# Altium schematic sheet lv_io_retro.SchDoc
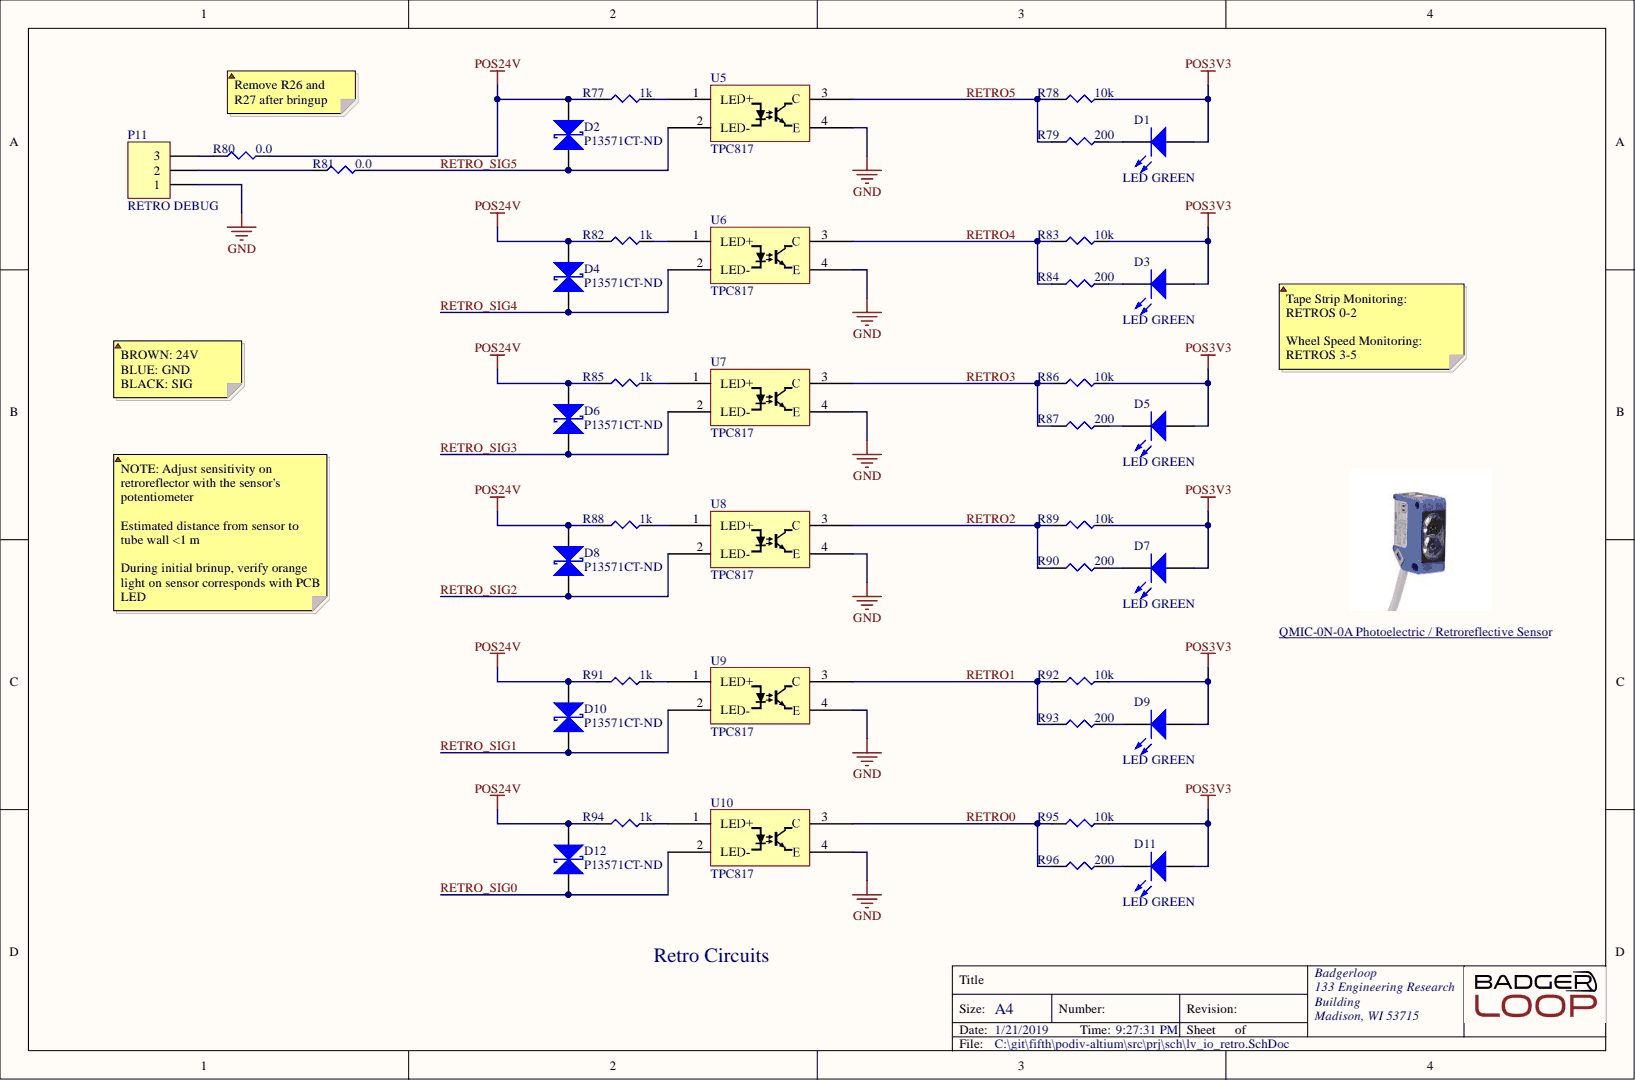
[diagram p0: lv_io_retro.SchDoc]

## Parts
D1  LED GREEN  Color: GREEN  [LED 0603 GREEN]
D2  Digikey P/N: P13571CT-ND  [SMD-0402-RES]  (hidden comment: ESD Diode)
D3  LED GREEN  Color: GREEN  [LED 0603 GREEN]
D4  Digikey P/N: P13571CT-ND  [SMD-0402-RES]  (hidden comment: ESD Diode)
D5  LED GREEN  Color: GREEN  [LED 0603 GREEN]
D6  Digikey P/N: P13571CT-ND  [SMD-0402-RES]  (hidden comment: ESD Diode)
D7  LED GREEN  Color: GREEN  [LED 0603 GREEN]
D8  Digikey P/N: P13571CT-ND  [SMD-0402-RES]  (hidden comment: ESD Diode)
D9  LED GREEN  Color: GREEN  [LED 0603 GREEN]
D10  Digikey P/N: P13571CT-ND  [SMD-0402-RES]  (hidden comment: ESD Diode)
D11  LED GREEN  Color: GREEN  [LED 0603 GREEN]
D12  Digikey P/N: P13571CT-ND  [SMD-0402-RES]  (hidden comment: ESD Diode)
P11  RETRO DEBUG  [Pin Header 1x3 TH Pitch 2.54mm]
R77  1k  [SMD-0603-RES]
R78  10k  [SMD-0603-RES]
R79  200  [SMD-0603-RES]
R80  0.0  [SMD-0402-RES]
R81  0.0  [SMD-0402-RES]
R82  1k  [SMD-0603-RES]
R83  10k  [SMD-0603-RES]
R84  200  [SMD-0603-RES]
R85  1k  [SMD-0603-RES]
R86  10k  [SMD-0603-RES]
R87  200  [SMD-0603-RES]
R88  1k  [SMD-0603-RES]
R89  10k  [SMD-0603-RES]
R90  200  [SMD-0603-RES]
R91  1k  [SMD-0603-RES]
R92  10k  [SMD-0603-RES]
R93  200  [SMD-0603-RES]
R94  1k  [SMD-0603-RES]
R95  10k  [SMD-0603-RES]
R96  200  [SMD-0603-RES]
U5  TPC817  [SOT200P1005X328-5N]
U6  TPC817  [SOT200P1005X328-5N]
U7  TPC817  [SOT200P1005X328-5N]
U8  TPC817  [SOT200P1005X328-5N]
U9  TPC817  [SOT200P1005X328-5N]
U10  TPC817  [SOT200P1005X328-5N]

## Nets
GND: P11.1 U5.4(E) U6.4(E) U7.4(E) U8.4(E) U9.4(E) U10.4(E)
POS3V3: D1.1 D3.1 D5.1 D7.1 D9.1 D11.1 R78.2 R83.2 R86.2 R89.2 R92.2 R95.2
POS24V: D2.2 D4.2 D6.2 D8.2 D10.2 D12.2 R77.1 R80.2 R82.1 R85.1 R88.1 R91.1 R94.1
RETRO0: R95.1 R96.1 U10.3(C)
RETRO1: R92.1 R93.1 U9.3(C)
RETRO2: R89.1 R90.1 U8.3(C)
RETRO3: R86.1 R87.1 U7.3(C)
RETRO4: R83.1 R84.1 U6.3(C)
RETRO5: R78.1 R79.1 U5.3(C)
RETRO_SIG0: D12.1 U10.2(LED-)
RETRO_SIG1: D10.1 U9.2(LED-)
RETRO_SIG2: D8.1 U8.2(LED-)
RETRO_SIG3: D6.1 U7.2(LED-)
RETRO_SIG4: D4.1 U6.2(LED-)
RETRO_SIG5: D2.1 R81.2 U5.2(LED-)
NetD1_2: D1.2 R79.2
NetD3_2: D3.2 R84.2
NetD5_2: D5.2 R87.2
NetD7_2: D7.2 R90.2
NetD9_2: D9.2 R93.2
NetD11_2: D11.2 R96.2
NetP11_2: P11.2 R81.1
NetP11_3: P11.3 R80.1
NetR77_2: R77.2 U5.1(LED+)
NetR82_2: R82.2 U6.1(LED+)
NetR85_2: R85.2 U7.1(LED+)
NetR88_2: R88.2 U8.1(LED+)
NetR91_2: R91.2 U9.1(LED+)
NetR94_2: R94.2 U10.1(LED+)

## Library data of the parts
D1 D3 D5 D7 D9 D11 = LED GREEN 0603: Manufacturer: Lite-On Inc.; Manufacturer P/N: LTST-C190KGKT; Component Kind: Standard; Digikey P/N: 160-1435-1-ND; Library Name: misc.SchLib; Package / Case: 0603
D2 D4 D6 D8 D10 D12 = ESD Diode: ESD Supressor; Manufacturer: EZAEG; Manufacturer P/N: Panasonic; Component Kind: Standard; Digikey Link: https://www.digikey.com/product-detail/en/panasonic-electronic-components/EZA-EG2A50AX/P13571CT-ND/1137239; Library Name: misc.SchLib
P11 = Header 3: Header, 3-Pin; Component Kind: Standard; LatestRevisionDate: 17-Jul-2002; LatestRevisionNote: Re-released for DXP Platform.; Library Name: connector.SchLib; Signal Integrity: Connector; Publisher: Altium Limited
R77 R82 R85 R88 R91 R94 = ERJ-P03F1001V: RES SMD 1K OHM 1% 1/5W 0603; Manufacturer: Panasonic Electronic Components; @ qty: 1500; Factory Stock: 0; Minimum Quantity: 1; Power (Watts): 0.2W, 1/5W; Temperature Coefficient: ±200ppm/°C; Unit Price (USD): 0.05227; Component Kind: Standard; Composition: Thick Film; Datasheets: https://industrial.panasonic.com/cdbs/www-data/pdf/RDA0000/AOA0000C243.pdf; Digi-Key Part Number: P16018CT-ND; Failure Rate: -; Features: Automotive AEC-Q200, Pulse Withstanding; Height - Seated (Max): 0.022" (0.55mm); Image: //media.digikey.com/Photos/Panasonic%20Photos/MFG_ERJ-P6W%20Series.jpg; Library Name: resistor.SchLib; Number of Terminations: 2; Operating Temperature: -55°C ~ 155°C; Package / Case: 0603 (1608 Metric); Packaging: Cut Tape (CT); Part Number: ERJ-P03F1001V; Part Status: Active; Quantity Available: 3331; Series: ERJ; Size / Dimension: 0.063" L x 0.031" W (1.60mm x 0.80mm); Supplier Device Package: 0603; Tolerance: ±1%; Value: 1k
R78 R83 R86 R89 R92 R95 = ERJ-P03J103V: RES SMD 10K OHM 5% 1/5W 0603; Manufacturer: Panasonic Electronic Components; @ qty: 1500; Factory Stock: 0; Minimum Quantity: 1; Power (Watts): 0.2W, 1/5W; Temperature Coefficient: ±200ppm/°C; Unit Price (USD): 0.03584; Component Kind: Standard; Composition: Thick Film; Datasheets: https://industrial.panasonic.com/cdbs/www-data/pdf/RDA0000/AOA0000C243.pdf; Digi-Key Part Number: P16032CT-ND; Failure Rate: -; Features: Automotive AEC-Q200, Pulse Withstanding; Height - Seated (Max): 0.022" (0.55mm); Image: //media.digikey.com/Photos/Panasonic%20Photos/MFG_ERJ-P6W%20Series.jpg; Library Name: resistor.SchLib; Number of Terminations: 2; Operating Temperature: -55°C ~ 155°C; Package / Case: 0603 (1608 Metric); Packaging: Cut Tape (CT); Part Number: ERJ-P03J103V; Part Status: Active; Quantity Available: 857; Series: ERJ; Size / Dimension: 0.063" L x 0.031" W (1.60mm x 0.80mm); Supplier Device Package: 0603; Tolerance: ±5%; Value: 10k
R79 R84 R87 R90 R93 R96 = ERJ-P03J201V: RES SMD 200 OHM 5% 1/5W 0603; Manufacturer: Panasonic Electronic Components; @ qty: 1500; Factory Stock: 0; Minimum Quantity: 1; Power (Watts): 0.2W, 1/5W; Temperature Coefficient: ±200ppm/°C; Unit Price (USD): 0.03584; Component Kind: Standard; Composition: Thick Film; Datasheets: https://industrial.panasonic.com/cdbs/www-data/pdf/RDA0000/AOA0000C243.pdf; Digi-Key Part Number: P16033CT-ND; Failure Rate: -; Features: Automotive AEC-Q200, Pulse Withstanding; Height - Seated (Max): 0.022" (0.55mm); Image: //media.digikey.com/Photos/Panasonic%20Photos/MFG_ERJ-P6W%20Series.jpg; Library Name: resistor.SchLib; Number of Terminations: 2; Operating Temperature: -55°C ~ 155°C; Package / Case: 0603 (1608 Metric); Packaging: Cut Tape (CT); Part Number: ERJ-P03J201V; Part Status: Active; Quantity Available: 6803; Series: ERJ; Size / Dimension: 0.063" L x 0.031" W (1.60mm x 0.80mm); Supplier Device Package: 0603; Tolerance: ±5%; Value: 200
R80 R81 = ERJ-2GE0R00X: RES SMD 0.0OHM JUMPER 1/10W 0402; Manufacturer: Panasonic Electronic Components; @ qty: 1500; Factory Stock: 0; Minimum Quantity: 1; Power (Watts): 0.1W, 1/10W; Unit Price (USD): 0.00384; Component Kind: Standard; Composition: Thick Film; Datasheets: http://industrial.panasonic.com/www-cgi/jvcr13pz.cgi?E+PZ+3+AOA0001+ERJ2GE0R00X+7+WW; Digi-Key Part Number: P0.0JCT-ND; Failure Rate: -; Features: Automotive AEC-Q200; Height - Seated (Max): 0.016" (0.40mm); Image: //media.digikey.com/Photos/Panasonic%20Photos/ERJ-PA2-Series.jpg; Library Name: resistor.SchLib; Number of Terminations: 2; Operating Temperature: -55°C ~ 155°C; Package / Case: 0402 (1005 Metric); Packaging: Cut Tape (CT); Part Number: ERJ-2GE0R00X; Part Status: Active; Quantity Available: 3152483; Series: ERJ; Size / Dimension: 0.039" L x 0.020" W (1.00mm x 0.50mm); Supplier Device Package: 0402; Temperature Coefficient: -; Tolerance: Jumper; Value: 0.0
U5-U10 = TPC817: OPTOISO 5KV TRANS 4SOP; Manufacturer: Taiwan Semi; Manufacturer P/N: TPC817S1B RAG; Component Kind: Standard; Digikey Link: https://www.digikey.com/product-detail/en/taiwan-semiconductor-corporation/TPC817S1B-RAG/TPC817S1BRAGCT-ND/7359679; Digikey P/N: TPC817S1BRAGCT-ND; Library Name: ic.SchLib
